# Altium schematic sheet Power.SchDoc
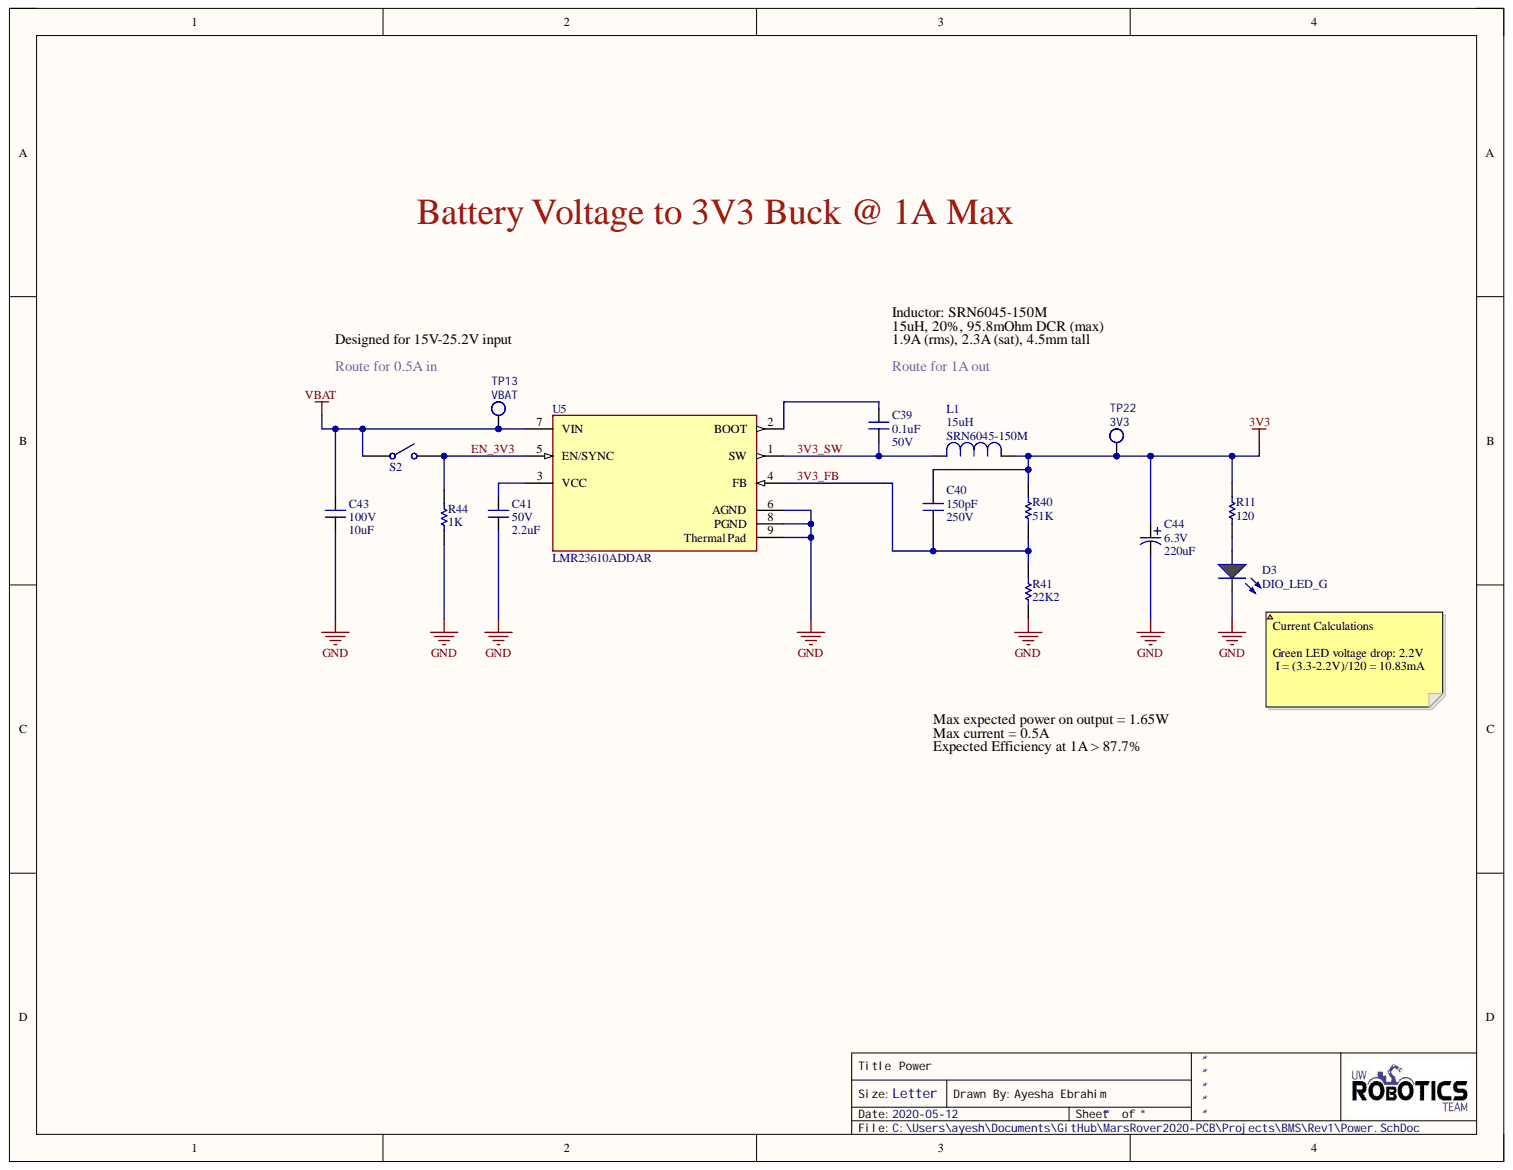
[diagram p0: Power.SchDoc]

## Parts
C39  value 0.1uF  Voltage Rating: 50V  [CAPC2012X140N]  (hidden comment: CAP_0.1uF_50V_0805)
C40  value 150pF  Capacitance: 150pF  Voltage Rating: 250V  [CAPC2012X140N]  (hidden comment: CAP_150pF_250V_0805)
C41  value 2.2uF  Capacitance: 2.2uF  Voltage: 50V  [CAPC1206(3216)]  (hidden comment: CAP_2.2uF_50V_1206)
C43  value 10uF  Voltage Rating: 100V  [CAPC1210(3225)]  (hidden comment: CAP_10uF_100V_1210)
C44  value 220uF  Voltage Rating: 6.3V  [PCAP_SVP_B6_PA00]  (hidden comment: CAP_220uF_6.3V_SMD)
D3  DIO_LED_G  [LED_0805_LINE]
L1  SRN6045-150M  Inductance: 15uH  [IND_BOURNS_SRN6045]
R11  value 120  [RESC0603(1608)_N]  (hidden comment: RES_120R_0603)
R40  value 51K  Resistance: 51K  [RESC2012X60N]  (hidden comment: RES_51K_0805)
R41  value 22K2  [RESC2012X60N]  (hidden comment: RES_22K1_0805)
R44  value 1K  Resistance: 1K  [RESC2012X60N]  (hidden comment: RES_1K_0805)
S2  GF-123-0054  [GF1230054]  (value not drawn)
TP13  VBAT  [TESTPOINT_LOOP]
TP22  3V3  [TESTPOINT_LOOP]
U5  LMR23610ADDAR  [8-SO PowerPad]

## Nets
3V3: C40.1 C44.1 L1.1 R11.2 R40.2 TP22.1
GND: C41.1 C43.1 C44.2 D3.2 R41.1 R44.1 U5.6(AGND) U5.8(PGND) U5.9(Thermal_Pad)
VBAT: C43.2 S2.1 TP13.1 U5.7(VIN)
3V3_FB: C40.2 R40.1 R41.2 U5.4(FB)
3V3_SW: C39.1 L1.2 U5.1(SW)
EN_3V3: R44.2 S2.2 U5.5(EN/SYNC)
NetC39_2: C39.2 U5.2(BOOT)
NetC41_2: C41.2 U5.3(VCC)
NetD3_1: D3.1 R11.1

## Library data of the parts
C39 = CAP_0.1uF_50V_0805: CAP CER 0.1UF 50V X7R 0805; Manufacturer 1: Murata Electronics; Manufacturer Part Number 1: GCD21BR71H104KA01L; Capacitance: 100nF; Tolerance: 10%; Case Code (Imperial): 0805; Case Code (Metric): 2012; Case/Package: 0805; Component Kind: Standard; ComponentLink1Description: https://datasheet.ciiva.com/29537/c03e-29537701.pdf?src-supplier=Digi-Key; ComponentLink1URL: https://datasheet.ciiva.com/29537/c03e-29537701.pdf?src-supplier=Digi-Key; Depth: 1.25mm; Dielectric: X7R; Height: 1.25mm; Length: 2mm; Max Operating Temperature: 125°C; Min Operating Temperature: -55°C; Mount: Surface Mount; Ratings: AEC-Q200; Supplier 1: Digi-Key; Supplier Part Number 1: 490-11955-1-ND; Termination: SMD/SMT; Thickness: 0.049inch; Width: 0.049inch; Library Name: UWRT_Schematic_Library_2020.SchLib
C40 = CAP_150pF_250V_0805: CAP CER 150PF 250V C0G/NP0 0805; Manufacturer 1: Murata Electronics; Manufacturer Part Number 1: GRM21A5C2E151JW01D; Dielectric: C0G, NP0; Tolerance: 5%; Case Code (Imperial): 0805; Case Code (Metric): 2012; Case/Package: 0805; Component Kind: Standard; Max Operating Temperature: 125°C; Min Operating Temperature: -55°C; Mount: Surface Mount; Supplier 1: Digi-Key; Supplier Part Number 1: 490-12755-1-ND; Termination: SMD/SMT; Width: 0.049inch; Library Name: UWRT_Schematic_Library_2020.SchLib
C41 = CAP_2.2uF_50V_1206: CAP CER 2.2UF 50V X7R 1206; Manufacturer 1: Murata Electronics; Manufacturer Part Number 1: GCM31CR71H225KA55L; Tolerance: 10%; Case Code (Imperial): 1206; Case Code (Metric): 3216; Case/Package: 1206; Category: Ceramic Capacitors; Component Kind: Standard; Depth: 1.6mm; Dielectric: X7R; Height: 1.6mm; Lead Free: Lead Free; Length: 3.2mm; Max Operating Temperature: 125°C; Min Operating Temperature: -55°C; Package Quantity: 1; Packaging: Tape and Reel; Pricing 2: 1=0.54000, 10=0.38400, 100=0.25300, 500=0.18742, 1000=0.15931 (USD); Radiation Hardening: No; Ratings: AEC-Q200; REACH SVHC: No SVHC; RoHS: Yes; Signal Integrity: Cap; Simulation: CAP; Stock 2: 102080; Supplier 1: Digi-Key; Supplier Part Number 1: 490-4796-1-ND; Termination: SMD/SMT; Thickness: 0.071inch; Voltage Rating: 50V; Voltage Rating (DC): 50V; Width: 1.6mm; Library Name: UWRT_Schematic_Library_2020.SchLib
C43 = CAP_10uF_100V_1210: CAP CER 10UF 100V X7S 1210; Manufacturer 1: Murata Electronics; Manufacturer Part Number 1: GRM32EC72A106KE05L; Component Kind: Standard; Mount: Surface Mount; Package: 1210 (3225 Metric); Signal Integrity: Cap; Simulation: CAP; Supplier 1: Digi-Key; Supplier Part Number 1: 490-16266-2-ND; Temperature Coefficient: X7S; Library Name: UWRT_Schematic_Library_2020.SchLib
C44 = CAP_220uF_6.3V_SMD: CAP ALUM POLY 220UF 20% 6.3V SMD; Manufacturer 1: Panasonic Electronic Components; Manufacturer Part Number 1: 6SVPE220MW; Component Kind: Standard; Mount: Surface Mount; Package: Radial, Can - SMD; Signal Integrity: Cap; Simulation: CAP; Supplier 1: Digi-Key; Supplier Part Number 1: P16836CT-ND; Library Name: UWRT_Schematic_Library_2020.SchLib
D3 = DIO_LED_G: LED GREEN DIFFUSED 0805 SMD; Manufacturer 1: OSRAM; Manufacturer Part Number 1: LG R971-KN-1; Forward Voltage Drop: 2.2V; Component Kind: Standard; Mount: Surface Mount; Package: 0805 (2012 Metric); Simulation: LED1; Supplier 1: Digi-Key; Supplier Part Number 1: 475-1410-1-ND; Library Name: UWRT_Schematic_Library_2020.SchLib
L1 = IND_15uH_1.9A: FIXED IND 15UH 1.9A 95.8MOHM SMD; Manufacturer 1: Bourns Inc.; Core Material: Ferrite; DC Resistance (DCR): 95.8mR; Inductance Tolerance: 20%; Saturation Current: 2.3A; Self Resonant Frequency: 13MHz; Shielding: Shielded; Test Frequency: 100kHz; Case/Package: Nonstandard; Category: Fixed Inductors; Component Kind: Standard; ComponentLink1Description: https://datasheet.ciiva.com/5986/1705518-5986195.pdf?src-supplier=Farnell; ComponentLink1URL: https://datasheet.ciiva.com/5986/1705518-5986195.pdf?src-supplier=Farnell; ComponentLink2Description: https://datasheet.ciiva.com/11802/0900766b80fe1f3e-11802573.pdf?src-supplier=RSComponents; ComponentLink2URL: https://datasheet.ciiva.com/11802/0900766b80fe1f3e-11802573.pdf?src-supplier=RSComponents; ComponentLink3Description: https://datasheet.ciiva.com/1056/srn6045-1056107.pdf?src-supplier=Digi-Key; ComponentLink3URL: https://datasheet.ciiva.com/1056/srn6045-1056107.pdf?src-supplier=Digi-Key; ComponentLink4Description: https://datasheet.ciiva.com/8512/srn6045-8512989.pdf?src-supplier=Avnet; ComponentLink4URL: https://datasheet.ciiva.com/8512/srn6045-8512989.pdf?src-supplier=Avnet; ComponentLink5Description: https://datasheet.ciiva.com/17113/70231653-17113165.pdf?src-supplier=Allied+Electronics; ComponentLink5URL: https://datasheet.ciiva.com/17113/70231653-17113165.pdf?src-supplier=Allied+Electronics; ComponentLink6Description: https://datasheet.ciiva.com/17284/srn6045-17284750.pdf?src-supplier=Avnet+Europe; ComponentLink6URL: https://datasheet.ciiva.com/17284/srn6045-17284750.pdf?src-supplier=Avnet+Europe; ComponentLink7Description: https://datasheet.ciiva.com/4954/srn6045-70649-4954231.pdf?src-supplier=Mouser; ComponentLink7URL: https://datasheet.ciiva.com/4954/srn6045-70649-4954231.pdf?src-supplier=Mouser; ComponentLink8Description: https://datasheet.ciiva.com/1056/srn6045-1056106.pdf?src-supplier=Digi-Key; ComponentLink8URL: https://datasheet.ciiva.com/1056/srn6045-1056106.pdf?src-supplier=Digi-Key; ComponentLink9Description: https://datasheet.ciiva.com/1056/srn6045-1056108.pdf?src-supplier=Digi-Key; ComponentLink9URL: https://datasheet.ciiva.com/1056/srn6045-1056108.pdf?src-supplier=Digi-Key; Composition: Wirewound; Current Rating: 1.9A; DC Current: 1.9A; Depth: 6.2mm; Height: 4.5mm; Height - Seated (Max): 0.177inch; Length: 6mm; Manufacturer Part Number 1: SRN6045-150M; Max DC Current: 1.9A; Max Operating Temperature: 125°C; Military Standard: Not; Min Operating Temperature: -40°C; Mount: Surface Mount; Number of Pins: 2; Package Quantity: 1000; Packaging: Tape and Reel; Pricing 1: 1=0.60000, 10=0.52300, 100=0.37380, 500=0.31396 (USD); REACH SVHC: No SVHC; RMS Current (Irms): 1.9A; RoHS: Yes; Stock 1: 16841; Supplier 1: Digi-Key; Supplier Part Number 1: SRN6045-150MCT-ND; Termination: SMD/SMT; Tolerance: 20%; Weight: 0.027725oz; Width: 6mm; Library Name: UWRT_Schematic_Library_2020.SchLib
R11 = RES_120R_0603: RES SMD 120 OHM 5% 1/10W 0603; Manufacturer 1: Yageo; Manufacturer Part Number 1: RC0603JR-07120RL; Component Kind: Standard; Dimensions: 0.063" L x 0.031" W (1.60mm x 0.80mm); Mount: Surface Mount; Package: 0603 (1608 Metric); Signal Integrity: Res; Simulation: RESISTOR; Supplier 1: Digi-Key; Supplier Part Number 1: 311-120GRCT-ND; Tolerance: 5%; Library Name: UWRT_Schematic_Library_2020.SchLib
R40 = RES_51K_0805: RES SMD 51K OHM 1% 1/8W 0805; Manufacturer 1: Vishay Dale; Manufacturer Part Number 1: CRCW080551K0FKEA; Power Rating: 125mW; Case Code (Imperial): 0805; Case Code (Metric): 2012; Component Kind: Standard; Max Operating Temperature: 155°C; Min Operating Temperature: -55°C; Supplier 1: Digi-Key; Supplier Part Number 1: 541-51.0KCCT-ND; Termination: SMD/SMT; Tolerance: 1%; Library Name: UWRT_Schematic_Library_2020.SchLib
R41 = RES_22K1_0805: RES SMD 22.1K OHM 1% 1/8W 0805; Manufacturer 1: Vishay Dale; Manufacturer Part Number 1: CRCW080522K1FKEA; Power Rating: 125mW; Case Code (Imperial): 0805; Case Code (Metric): 2012; Component Kind: Standard; Max Operating Temperature: 155°C; Min Operating Temperature: -55°C; Resistance: 22.1K; Supplier 1: Digi-Key; Supplier Part Number 1: 541-22.1KCCT-ND; Termination: SMD/SMT; Tolerance: 1%; Library Name: UWRT_Schematic_Library_2020.SchLib
R44 = RES_1K_0805: RES SMD 1K OHM 1% 1/8W 0805; Manufacturer 1: Yageo; Manufacturer Part Number 1: RC0805FR-071KL; Power Rating: 125mW; Case Code (Imperial): 0805; Case Code (Metric): 2012; Component Kind: Standard; Max Operating Temperature: 155°C; Min Operating Temperature: -55°C; Supplier 1: Digi-Key; Supplier Part Number 1: 311-1.00KCRCT-ND; Termination: SMD/SMT; Tolerance: 1%; Library Name: UWRT_Schematic_Library_2020.SchLib
S2 = GF-123-0054: SWITCH SLIDE SPST 500mA (DC) 8.5A (AC) 125V; Manufacturer 1: CW Industries; Component Kind: Standard; Current Rating: 500mA; Manufacturer Part Number 1: GF-123-0054; Supplier 1: Digi-Key; Supplier Part Number 1: CWI333-ND; Voltage Rating: 125V; Library Name: UWRT_Schematic_Library_2020.SchLib
TP13 TP22 = TESTPOINT_LOOP: Loop testpoint through-hole pad; Manufacturer 1: Keystone Electronics; Manufacturer Part Number 1: 5002; Component Kind: Standard; Mount: Through Hole; Supplier 1: Digi-Key; Supplier Part Number 1: 36-5002-ND; Library Name: UWRT_Schematic_Library_2020.SchLib
U5 = BUCK_1-28VOUT_1A: IC REG BUCK ADJUSTABLE 1A 8SOPWR; Manufacturer 1: Texas Instruments; Max Duty Cycle: 97%; Max Junction Temp: +125°C; Max Supply Voltage: 36V; Min Supply Voltage: 4V; Nominal Supply Current: 75uA; Number Of Outputs: 1; Output Type: AdjustableProgrammable; Output Voltage: 1-28V; Standoff Height: 0mm; Switching Frequency: 400kHz; Automotive: No; Category: IC; Component Kind: Standard; ComponentLink2Description: Datasheet; ComponentLink2URL: http://www.ti.com/lit/ds/symlink/lmr23610.pdf; Device Class L1: Integrated Circuits (ICs); Device Class L2: Power Management ICs; Device Class L3: Voltage Regulators - Switching; Digikey Description: IC REG BUCK ADJUSTABLE 1A 8SOPWR; Height: 1.7mm; Lead Free: Yes; Manufacturer Part Number 1: LMR23610ADDAR; Octopart: https://octopart.com/lmr23610addar-texas+instruments-68058948; Output Current: 1A; Package: DDA0008E; RoHS: TRUE; Supplier 1: Digi-Key; Supplier Part Number 1: 296-47267-1-ND; Switching Topology: Buck; Temperature: -40°C to +125°C; Temperature Range High: +125°C; Temperature Range Low: -40°C; Library Name: UWRT_Schematic_Library_2020.SchLib
